# Altium schematic sheet 光照模块.SchDoc
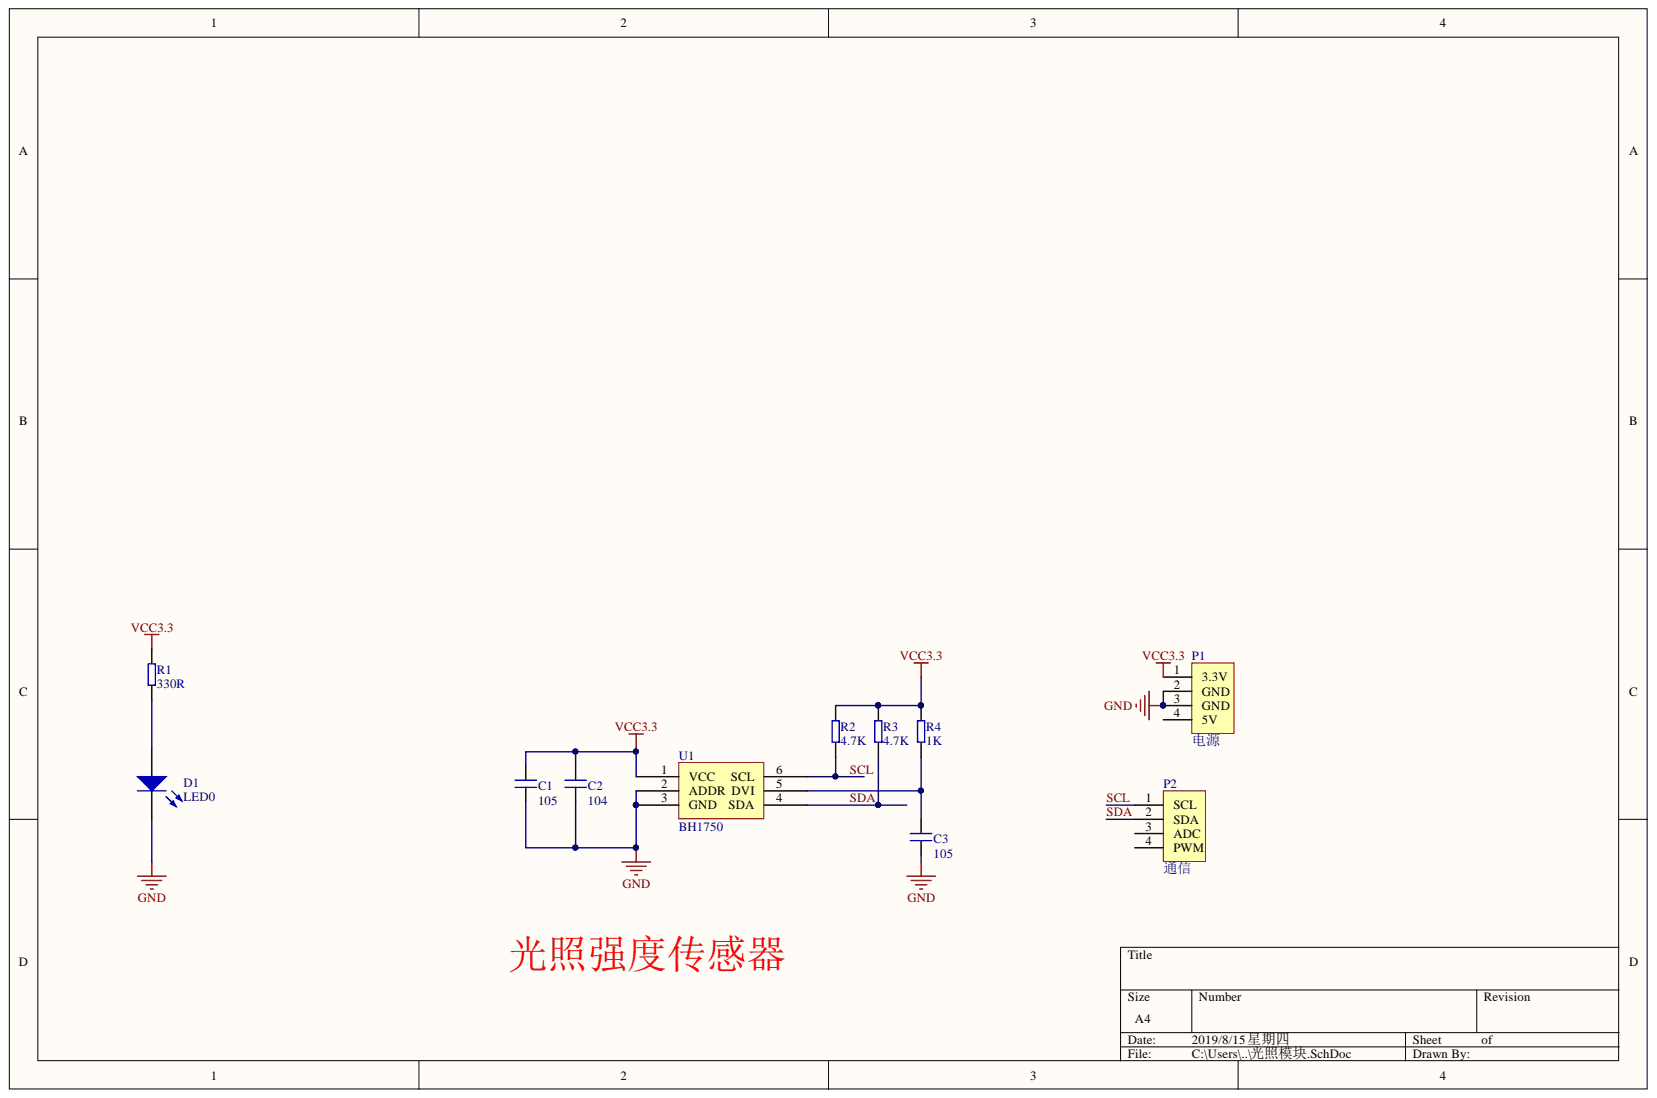
[diagram p0: 光照模块.SchDoc]

## Parts
C1  value 105  [0805CAP]  (hidden comment: CAP)
C2  value 104  [0805CAP]  (hidden comment: CAP)
C3  value 105  [0805CAP]  (hidden comment: CAP)
D1  LED0  [LED-0805]
P1  电源  [HDR1X10]
P2  通信  [HDR1X10]
R1  value 330R  [0805]  (hidden comment: RES)
R2  value 4.7K  [0805]  (hidden comment: RES)
R3  value 4.7K  [0805]  (hidden comment: RES)
R4  value 1K  [0805]  (hidden comment: RES)
U1  BH1750  [BH1750]

## Nets
GND: C1.1 C2.1 C3.1 D1.2 P1.2(GND) P1.3(GND) U1.2(ADDR) U1.3(GND)
VCC3.3: C1.2 C2.2 P1.1(3.3V) R1.2 R2.2 R3.2 R4.2 U1.1(VCC)
SCL: P2.1(SCL) R2.1 U1.6(SCL)
SDA: P2.2(SDA) R3.1 U1.4(SDA)
NetC3_2: C3.2 R4.1 U1.5(DVI)
NetD1_1: D1.1 R1.1

Not connected: P1 4(5V); P2 3(ADC) 4(PWM)

## Library data of the parts
D1 = LED0: Typical INFRARED GaAs LED; Component Kind: Standard; LatestRevisionDate: 08-Jul-2005; LatestRevisionNote: Mech Layer 1 removed.; Library Name: Miscellaneous Devices.IntLib; PackageDescription: LED; 2 Leads; PackageReference: LED-0; Published: 8-Jun-2000; Simulation: LED0; Publisher: Altium Limited
P1 P2 = Header 4: Header, 4-Pin; Component Kind: Standard; LatestRevisionDate: 17-Jul-2002; LatestRevisionNote: Re-released for DXP Platform.; Library Name: Miscellaneous Connectors.IntLib; Signal Integrity: Connector; Publisher: Altium Limited
C1-C3 = CAP: Component Kind: Standard; Description: CAP; Library Name: Basic.SchLib
R1-R4 = RES: Component Kind: Standard; Library Name: Basic.SchLib
U1 = BH1750: Component Kind: Standard; LatestRevisionDate: 17-Jul-2002; LatestRevisionNote: Re-released for DXP Platform.; Library Name: Basic.SchLib; Publisher: Altium Limited
